# Altium schematic sheet Schematic.SchDoc(Schematic)
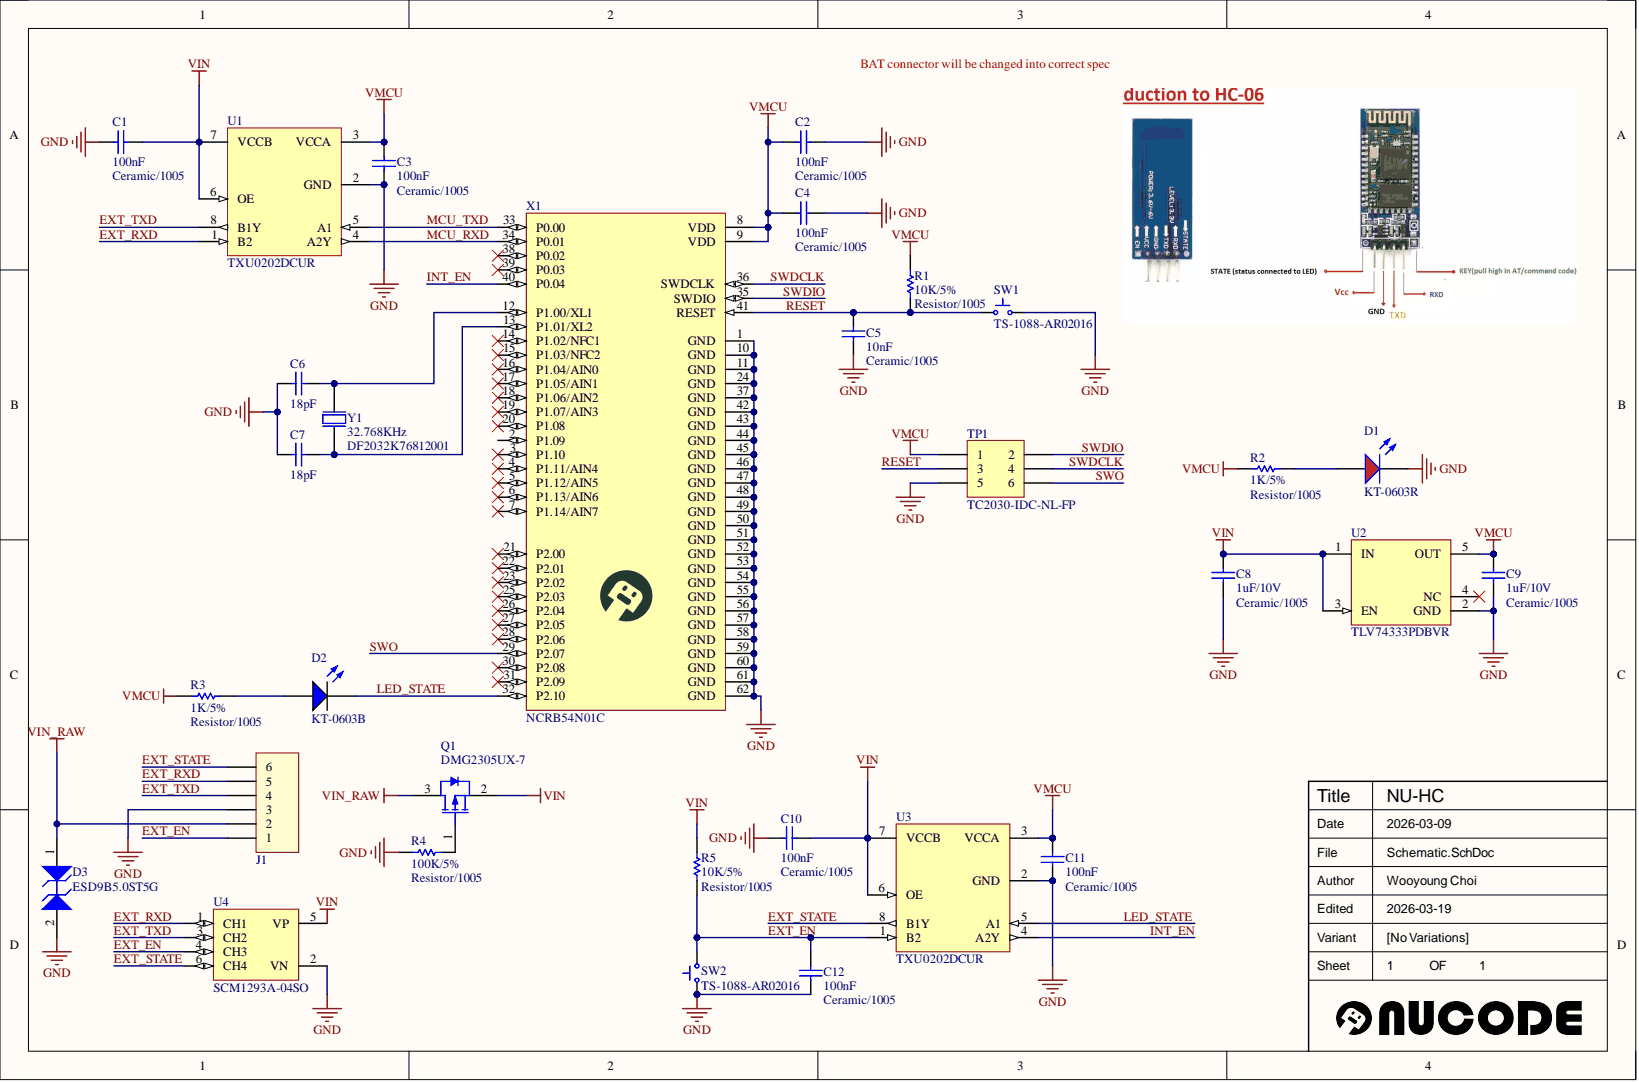
[diagram p0: Schematic.SchDoc]

## Parts
C1  Ceramic/1005  value 100nF
C2  Ceramic/1005  value 100nF
C3  Ceramic/1005  value 100nF
C4  Ceramic/1005  value 100nF
C5  Ceramic/1005  value 10nF
C6  Ceramic/1005  value 18pF
C7  Ceramic/1005  value 18pF
C8  Ceramic/1005  value 1uF/10V
C9  Ceramic/1005  value 1uF/10V
C10  Ceramic/1005  value 100nF
C11  Ceramic/1005  value 100nF
C12  Ceramic/1005  value 100nF
D1  KT-0603R
D2  KT-0603B
D3  ESD9B5.0ST5G
J1  X6511WR-06H-C60D30R1  (value not drawn)
Q1  DMG2305UX-7
R1  Resistor/1005  value 10K/5%
R2  Resistor/1005  value 1K/5%
R3  Resistor/1005  value 1K/5%
R4  Resistor/1005  value 100K/5%
R5  Resistor/1005  value 10K/5%
SW1  TS-1088-AR02016
SW2  TS-1088-AR02016
TP1  TC2030-IDC-NL-FP  (no BOM)
U1  TXU0202DCUR
U2  TLV74333PDBVR
U3  TXU0202DCUR
U4  SCM1293A-04SO
X1  NCRB54N01C
Y1  DF2032K76812001  value 32.768KHz

## Nets
GND: C1.2 C2.2 C3.1 C4.2 C5.1 C6.2 C7.2 C8.1 C9.1 C10.2 C11.1 C12.1 D1.2 D3.2 J1.3 R4.1 SW1.2 SW2.1 TP1.5 U1.2(GND) U2.2(GND) U3.2(GND) U4.2(VN) X1.1(GND) X1.10(GND) X1.11(GND) X1.24(GND) X1.37(GND) X1.42(GND) X1.43(GND) X1.44(GND) X1.45(GND) X1.46(GND) X1.47(GND) X1.48(GND) X1.49(GND) X1.50(GND) X1.51(GND) X1.52(GND) X1.53(GND) X1.54(GND) X1.55(GND) X1.56(GND) X1.57(GND) X1.58(GND) X1.59(GND) X1.60(GND) X1.61(GND) X1.62(GND)
VIN: C1.1 C8.2 C10.1 Q1.2 R5.2 U1.6(OE) U1.7(VCCB) U2.1(IN) U2.3(EN) U3.6(OE) U3.7(VCCB) U4.5(VP)
VIN_RAW: D3.1 J1.2 Q1.3
VMCU: C2.1 C3.2 C4.1 C9.2 C11.2 R1.2 R2.1 R3.1 TP1.1 U1.3(VCCA) U2.5(OUT) U3.3(VCCA) X1.8(VDD) X1.9(VDD)
EXT_EN: C12.2 J1.1 R5.1 SW2.2 U3.1(B2) U4.4(CH3)
EXT_RXD: J1.5 U1.1(B2) U4.1(CH1)
EXT_STATE: J1.6 U3.8(B1Y) U4.6(CH4)
EXT_TXD: J1.4 U1.8(B1Y) U4.3(CH2)
INT_EN: U3.4(A2Y) X1.40(P0.04)
LED_STATE: D2.2 U3.5(A1) X1.32(P2.10)
MCU_RXD: U1.4(A2Y) X1.34(P0.01)
MCU_TXD: U1.5(A1) X1.33(P0.00)
RESET: C5.2 R1.1 SW1.1 TP1.3 X1.41(RESET)
SWDCLK: TP1.4 X1.36(SWDCLK)
SWDIO: TP1.2 X1.35(SWDIO)
SWO: TP1.6 X1.29(P2.07)
NetC6_1: C6.1 X1.12(P1.00/XL1) Y1.2
NetC7_1: C7.1 X1.13(P1.01/XL2) Y1.1
NetD1_1: D1.1 R2.2
NetD2_1: D2.1 R3.2
NetQ1_1: Q1.1 R4.2

Not connected: U2 4(NC); X1 2(P1.09) 3(P1.10) 4(P1.11/AIN4) 5(P1.12/AIN5) 6(P1.13/AIN6) 7(P1.14/AIN7) 14(P1.02/NFC1) 15(P1.03/NFC2) 16(P1.04/AIN0) 17(P1.05/AIN1) 18(P1.06/AIN2) 19(P1.07/AIN3) 20(P1.08) 21(P2.00) 22(P2.01) 23(P2.02) 25(P2.03) 26(P2.04) 27(P2.05) 28(P2.06) 30(P2.08) 31(P2.09) 38(P0.02) 39(P0.03)

## Library data of the parts
C1-C12 = CMP-002-0012-2: Generic 1005 Ceramic Capacitor; Capacitor Type: Ceramic; Case/Package: 1005; Component Kind: Standard; Library Name: C6H6`s Vault; Mount: SMD; Pins: 2; RoHS: Yes
D1 = CMP-008-0034-1: Hubei KENTO Elec LED RED WATER CLEAR 0603 SMD 645nm - Discrete 2.4V; Component Kind: Standard; Library Name: C6H6`s Vault
D2 = CMP-008-0035-1: Hubei KENTO Elec LED BLUE WATER CLEAR 0603 SMD 469nm - Discrete 3.1V; Component Kind: Standard; Library Name: C6H6`s Vault
D3 = CMP-00930-1: 5.8V Bidirectional 5V SOD-923 ESD and Surge Protection (TVS/ESD) ROHS; Manufacturer Lifecycle Status: ACTIVE; Breakdown Voltage: 6.8V; Clamping Voltage: 12.5V; Element Configuration: Single; ESD Protection: Yes; Leakage Current: 1µA; Max Breakdown Voltage: 7.8V; Max Junction Temperature (Tj): 150°C; Max Power Dissipation: 300mW; Max Surge Current: 1A; Number of Bidirectional Channels: 1; Peak Pulse Current: 2A; Peak Reverse Current: 100nA; Polarity: Unidirectional; Power Line Protection: No; Test Current: 1mA; Value: 15pF; Case/Package: SOD-923-2; China RoHS: Compliant; Component Kind: Standard; Composition: Zener; Contact Plating: Tin; Country of Origin: Mainland China; Depth: 600µm; Direction: Bidirectional; Export Control Classification Number (ECCN) Code: EAR99; Halogen Free: Halogen Free; Harmonized Tariff Schedule (HTS) Code: 8541.10.00.50; Height: 400µm; Introduction Date: 2007-03-16; Lead Free: Lead Free; Length: 800µm; Library Name: C6H6`s Vault; Lifecycle Status: Production; Max Operating Temperature: 150°C; Max Reverse Leakage Current: 1µA; Min Breakdown Voltage: 5.8V; Min Operating Temperature: -55°C; Number of Channels: 1; Number of Elements: 1; Number of Lines: 1; Number of Terminals: 2; Operating Supply Voltage: 5V; Packaging: Tape and Reel; Peak Pulse Power: 300mW; Pins: 2; Radiation Hardening: No; REACH SVHC: Yes; Reverse Breakdown Voltage: 5.8V; Reverse Standoff Voltage: 5V; RoHS: Compliant; Schedule B: 8536300000, 8536300000; Termination: SMD/SMT; Width: 650µm; Working Voltage: 5V
J1 = CMP-00929-2: Gold 250V 3A Policy 2.5mm 6P -40℃~+105℃ 2.54mm Single Row Black Brass 1x6P 2.54mm Push-Pull, P=2.54mm Pin Headers ROHS; Component Kind: Standard; Library Name: C6H6`s Vault; Mount: TH; Pins: 6; RoHS: Yes
Q1 = CMP-ROOT-0375-1: P-Channel 20 V 52 mOhm Surface Mount Enhancement Mode Mosfet - SOT-23-3; Continuous Drain Current (ID): 4.2A; Drain to Source Breakdown Voltage: -20V; Drain to Source Resistance: 52mΩ; Element Configuration: Single; Fall Time: 34.7ns; Gate to Source Voltage (Vgs): 8V; Input Capacitance: 808pF; Max Junction Temperature (Tj): 150°C; Max Power Dissipation: 1.4W; Min Breakdown Voltage: 20V; Number of Channels: 1; Rise Time: 13.7ns; Threshold Voltage: -900mV; Turn-Off Delay Time: 79.3ns; Turn-On Delay Time: 10.8ns; Value: 52MΩ; Case/Package: SOT-23; China RoHS: Compliant; Component Kind: Standard; Contact Plating: Tin; Drain to Source Voltage (Vdss): -20V; Height: 1.1mm; Lead Free: Lead Free; Length: 3mm; Library Name: C6H6`s Vault; Lifecycle Status: Production; Max Operating Temperature: 150°C; Min Operating Temperature: -55°C; Mounting Technology: Surface Mount; Number of Elements: 1; Number of Terminals: 3; Packaging: Tape and Reel; Pins: 3; Power Dissipation: 1.4W; Radiation Hardening: No; Rds On Max: 52mΩ; REACH SVHC: Yes; RoHS: Compliant; Schedule B: 8541290080, 8541290080; Weight: 7.994566mg; Width: 1.4mm
R1-R5 = CMP-001-0019-3: Generic 1005 Resistor; Case/Package: Bulk; Component Kind: Standard; Library Name: C6H6`s Vault; Mount: SMD; RoHS: Yes
SW1 SW2 = CMP-013-0071-1: XUNPU Tactile Switch SPST 160gf 2mm SMD (SMT) Tab 4mm x 3mm Surface Mount; Case/Package: SMD; Component Kind: Standard; Library Name: C6H6`s Vault; Mount: SMD; Pins: 2; RoHS: Yes
TP1 = CMP-001-A00-2: Adapter Cable 6 Pos, 1.27mm POGO; Library Name: C6H6`s Vault; Package Quantity: 1; Pins: 6
U1 U3 = CMP-006-0430-1: Two channel fixed-direction level shifter with channels in opposite direction 8-VSSOP -40 to 125; Manufacturer Lifecycle Status: ACTIVE; Number of Bits: 2; Topology: Push-Pull; Case/Package: VSSOP; Component Kind: Standard; Height: 900µm; Length: 2.3mm; Library Name: C6H6`s Vault; Lifecycle Status: Production; Pins: 8; RoHS: Compliant; Thickness: 850µm; Width: 2mm
U2 = CMP-006-0431-2: 300mA low-Iq low-dropout (LDO) voltage regulator with enable 5-SOT-23 -40 to 125; Manufacturer Lifecycle Status: ACTIVE; Accuracy: 1%; Dropout Voltage: 125mV; Max Junction Temperature (Tj): 125°C; Max Supply Voltage: 5.5V; Min Supply Voltage: 1.4V; Nominal Supply Current: 34µA; Number of Channels: 1; Output Voltage: 3.3V; Case/Package: SOT-23; Component Kind: Standard; Height: 1.45mm; Length: 2.9mm; Library Name: C6H6`s Vault; Lifecycle Status: Production; Max Operating Temperature: 125°C; Min Operating Temperature: -40°C; Number of Outputs: 1; Output Current: 300mA; Pins: 5; RoHS: Compliant; Schedule B: 8542390000, 8542390000; Thickness: 1.2mm; Width: 1.6mm
U4 = CMP-00932-3: 10V (Typ) Clamp 1A (8/20s) Ipp Tvs Diode Surface Mount SC-74; Clamping Voltage: 9.9V; Direction: Unidirectional; Number of Channels: 4; Value: 2pF; Component Kind: Standard; Height: 1.1mm; Library Name: C6H6`s Vault; Max Operating Temperature: 85°C; Min Operating Temperature: -40°C; Peak Pulse Current: 1A; RoHS: Compliant
X1 = CMP-001-000006-2: NU-54, BLE module based on NRF54L05, 512KB memory, Chip antenna type; Component Kind: Standard; Library Name: C6H6`s Vault
Y1 = CMP-015-0066-1: Crystal 32.768kHz ±20ppm 12.5pF 70kΩ SMD3215-2P; Case/Package: 3215; Component Kind: Standard; Library Name: C6H6`s Vault; Mount: SMD; Pins: 2; RoHS: Yes
